# Altium schematic sheet AnalogSignalPath.SchDoc(AnalogSignalPath) (continued)

## Library data of the parts
C25-C32 = C0603C104K5RACAUTO: Multilayer Ceramic Capacitors MLCC - SMD/SMT 50V 0.1uF X7R 0603 10% AEC-Q200; Manufacturer: Yageo Group; Dissipation Factor: 2.5%; Temperature Coefficient: 30ppm/°C; Value: 100nF; Case Code (Imperial): 0603; Case Code (Metric): 1608; Case/Package: 0603; Category: Ceramic Capacitors; China RoHS: Compliant; Component Kind: Standard; ComponentLink1Description: https://datasheet.octopart.com:443/C0603C104K5RACAUTO-KEMET-datasheet-178087915.pdf?src-supplier=Farnell; ComponentLink1URL: https://datasheet.octopart.com:443/C0603C104K5RACAUTO-KEMET-datasheet-178087915.pdf?src-supplier=Farnell; ComponentLink2Description: https://datasheet.octopart.com:443/C0603C104K5RACAUTO-KEMET-datasheet-140480346.pdf?src-supplier=Farnell; ComponentLink2URL: https://datasheet.octopart.com:443/C0603C104K5RACAUTO-KEMET-datasheet-140480346.pdf?src-supplier=Farnell; ComponentLink3Description: https://datasheet.octopart.com:443/C0603C104K5RACAUTO-KEMET-datasheet-138896278.pdf?src-supplier=Farnell; ComponentLink3URL: https://datasheet.octopart.com:443/C0603C104K5RACAUTO-KEMET-datasheet-138896278.pdf?src-supplier=Farnell; ComponentLink4Description: https://datasheet.octopart.com:443/C0603C104K5RACAUTO-KEMET-datasheet-101575653.pdf?src-supplier=Farnell; ComponentLink4URL: https://datasheet.octopart.com:443/C0603C104K5RACAUTO-KEMET-datasheet-101575653.pdf?src-supplier=Farnell; ComponentLink5Description: https://datasheet.octopart.com:443/C0603C104K5RACAUTO-KEMET-datasheet-169773475.pdf?src-supplier=Farnell; ComponentLink5URL: https://datasheet.octopart.com:443/C0603C104K5RACAUTO-KEMET-datasheet-169773475.pdf?src-supplier=Farnell; ComponentLink6Description: https://datasheet.octopart.com:443/C0603C104K5RAC-AUTO-Kemet-datasheet-11517047.pdf?src-supplier=Farnell; ComponentLink6URL: https://datasheet.octopart.com:443/C0603C104K5RAC-AUTO-Kemet-datasheet-11517047.pdf?src-supplier=Farnell; ComponentLink7Description: https://datasheet.octopart.com:443/C0603C104K5RACAUTO-KEMET-datasheet-137357764.pdf?src-supplier=Upverter; ComponentLink7URL: https://datasheet.octopart.com:443/C0603C104K5RACAUTO-KEMET-datasheet-137357764.pdf?src-supplier=Upverter; ComponentLink8Description: https://datasheet.octopart.com:443/C0603C104K5RACAUTO-Kemet-datasheet-17277841.pdf?src-supplier=Newark; ComponentLink8URL: https://datasheet.octopart.com:443/C0603C104K5RACAUTO-Kemet-datasheet-17277841.pdf?src-supplier=Newark; ComponentLink9Description: https://datasheet.octopart.com:443/C0603C104K5RAC-AUTO-Kemet-datasheet-10850653.pdf?src-supplier=Newark; ComponentLink9URL: https://datasheet.octopart.com:443/C0603C104K5RAC-AUTO-Kemet-datasheet-10850653.pdf?src-supplier=Newark; ComponentLink10Description: https://datasheet.octopart.com:443/C0603C104K5RACAUTO-KEMET-datasheet-176289396.pdf?src-supplier=JRH+Electronics; ComponentLink10URL: https://datasheet.octopart.com:443/C0603C104K5RACAUTO-KEMET-datasheet-176289396.pdf?src-supplier=JRH+Electronics; ComponentLink11Description: https://datasheet.octopart.com:443/C0603C104K5RACAUTO-KEMET-datasheet-153410967.pdf?src-supplier=Electro+Sonic; ComponentLink11URL: https://datasheet.octopart.com:443/C0603C104K5RACAUTO-KEMET-datasheet-153410967.pdf?src-supplier=Electro+Sonic; ComponentLink12Description: https://datasheet.octopart.com:443/C0603C104K5RACAUTO-KEMET-datasheet-142166320.pdf?src-supplier=Electro+Sonic; ComponentLink12URL: https://datasheet.octopart.com:443/C0603C104K5RACAUTO-KEMET-datasheet-142166320.pdf?src-supplier=Electro+Sonic; ComponentLink13Description: https://datasheet.octopart.com:443/C0603C104K5RACAUTO-Kemet-datasheet-66076775.pdf?src-supplier=element14+APAC; ComponentLink13URL: https://datasheet.octopart.com:443/C0603C104K5RACAUTO-Kemet-datasheet-66076775.pdf?src-supplier=element14+APAC; ComponentLink14Description: https://datasheet.octopart.com:443/C0603C104K5RACAUTO-Kemet-datasheet-66204749.pdf?src-supplier=element14+APAC; ComponentLink14URL: https://datasheet.octopart.com:443/C0603C104K5RACAUTO-Kemet-datasheet-66204749.pdf?src-supplier=element14+APAC; ComponentLink15Description: https://datasheet.octopart.com:443/C0603C104K5RACAUTO-Kemet-datasheet-66534724.pdf?src-supplier=element14+APAC; ComponentLink15URL: https://datasheet.octopart.com:443/C0603C104K5RACAUTO-Kemet-datasheet-66534724.pdf?src-supplier=element14+APAC; ComponentLink16Description: https://datasheet.octopart.com:443/C0603C104K5RACAUTO-KEMET-datasheet-83968784.pdf?src-supplier=TME; ComponentLink16URL: https://datasheet.octopart.com:443/C0603C104K5RACAUTO-KEMET-datasheet-83968784.pdf?src-supplier=TME; ComponentLink17Description: https://datasheet.octopart.com:443/C0603C104K5RACAUTO-KEMET-datasheet-83186888.pdf?src-supplier=TME; ComponentLink17URL: https://datasheet.octopart.com:443/C0603C104K5RACAUTO-KEMET-datasheet-83186888.pdf?src-supplier=TME; ComponentLink18Description: https://datasheet.octopart.com/C0603C104K5RACAUTO-KEMET-datasheet-84169754.pdf; ComponentLink18URL: https://datasheet.octopart.com/C0603C104K5RACAUTO-KEMET-datasheet-84169754.pdf; ComponentLink19Description: https://datasheet.octopart.com:443/C0603C104K5RACAUTO-KEMET-datasheet-189767472.pdf?src-supplier=ODG+(Origin+Data+Global); ComponentLink19URL: https://datasheet.octopart.com:443/C0603C104K5RACAUTO-KEMET-datasheet-189767472.pdf?src-supplier=ODG+(Origin+Data+Global); ComponentLink20Description: http://sigma.octopart.com:80/conflict_mineral_statement/Yageo-company-3.pdf?src-supplier=Compliance+Statements; ComponentLink20URL: http://sigma.octopart.com:80/conflict_mineral_statement/Yageo-company-3.pdf?src-supplier=Compliance+Statements; ComponentLink21Description: http://sigma.octopart.com:80/rohs_statement/Yageo-company-2.pdf?src-supplier=Compliance+Statements; ComponentLink21URL: http://sigma.octopart.com:80/rohs_statement/Yageo-company-2.pdf?src-supplier=Compliance+Statements; Contact Plating: Tin; Country of Origin: Mexico; Depth: 800µm; Dielectric: X7R; Dielectric Material: Ceramic; Export Control Classification Number (ECCN) Code: EAR99; Harmonized Tariff Schedule (HTS) Code: 8532.24.00.20; Height: 800µm; Introduction Date: 2011-07-27; Length: 1.6mm; Lifecycle Status: Production; Manufacturer Part Number: C0603C104K5RACAUTO; Material: Ceramic; Max Operating Temperature: 125°C; Min Operating Temperature: -55°C; Mounting Technology: Surface Mount; Number of Terminals: 2; Packaging: Cut Tape; Pricing: 1=0.15, 10=0.083, 50=0.0574, 100=0.0497, 500=0.0367 (USD); Radiation Hardening: No; Ratings: AEC-Q200; REACH SVHC: Yes; RoHS: Compliant; Schedule B: 8532240020, 8532240020|8532240020, 8532240020|8532240020|8532240020, 8532240020|8532240020|8532240020|8532240020; Stock: 1408607; Supplier: DigiKey; Supplier Part Number: 399-6856-6-ND; Termination: SMD/SMT; Thickness: 863.6µm; Tolerance: 10%; Voltage Rating: 50V; Voltage Rating (DC): 50V; Width: 800µm; Library Name: Tremelo_Pedal.SchLib
C33 = R82DC3100AA50K: Res Thick Film 0603 10.7K Ohm 1% 0.1W(1/10W) ±100ppm/C Pad SMD T/R Automotive AEC-Q200; Manufacturer: Yageo Group; Manufacturer Lifecycle Status: ACTIVE; Breakdown Voltage: -35V; Continuous Drain Current (ID): 2mA; Dielectric: PET; Dielectric Material: Polyester; Dissipation Factor: 80%; Drain to Source Resistance: 100Ω; Drain to Source Voltage (Vdss): 35V; Element Configuration: Single; Lead Diameter: 500µm; Max Junction Temperature (Tj): 150°C; Max Power Dissipation: 350mW; Number of Channels: 1; Power Rating: 100mW; Temperature Coefficient: 100ppm/°C; Tolerance: 10%; Type: General Purpose; Value: 100nF; Voltage Rating: 63V; Voltage Rating (AC): 40V; Working Voltage: 75V; Case/Package: Radial; Category: Film Capacitors; China RoHS: Compliant; Component Kind: Standard; ComponentLink1Description: https://datasheet.octopart.com:443/R82DC3100AA50K-KEMET-datasheet-182036371.pdf?src-supplier=IHS; ComponentLink1URL: https://datasheet.octopart.com:443/R82DC3100AA50K-KEMET-datasheet-182036371.pdf?src-supplier=IHS; ComponentLink2Description: https://datasheet.octopart.com:443/R82DC3100AA50K-KEMET-datasheet-27203286.pdf?src-supplier=Farnell; ComponentLink2URL: https://datasheet.octopart.com:443/R82DC3100AA50K-KEMET-datasheet-27203286.pdf?src-supplier=Farnell; ComponentLink3Description: https://datasheet.octopart.com:443/R82DC3100AA50K-KEMET-datasheet-86450214.pdf?src-supplier=Farnell; ComponentLink3URL: https://datasheet.octopart.com:443/R82DC3100AA50K-KEMET-datasheet-86450214.pdf?src-supplier=Farnell; ComponentLink4Description: https://datasheet.octopart.com:443/R82DC3100AA50K-KEMET-datasheet-181970238.pdf?src-supplier=Farnell; ComponentLink4URL: https://datasheet.octopart.com:443/R82DC3100AA50K-KEMET-datasheet-181970238.pdf?src-supplier=Farnell; ComponentLink5Description: https://datasheet.octopart.com:443/R82DC3100AA50K-KEMET-datasheet-181970237.pdf?src-supplier=Farnell; ComponentLink5URL: https://datasheet.octopart.com:443/R82DC3100AA50K-KEMET-datasheet-181970237.pdf?src-supplier=Farnell; ComponentLink6Description: https://datasheet.octopart.com:443/R82DC3100AA50K-KEMET-datasheet-182521466.pdf?src-supplier=Farnell; ComponentLink6URL: https://datasheet.octopart.com:443/R82DC3100AA50K-KEMET-datasheet-182521466.pdf?src-supplier=Farnell; ComponentLink7Description: https://datasheet.octopart.com:443/R82DC3100AA50K-KEMET-datasheet-83037516.pdf?src-supplier=Newark; ComponentLink7URL: https://datasheet.octopart.com:443/R82DC3100AA50K-KEMET-datasheet-83037516.pdf?src-supplier=Newark; ComponentLink8Description: https://datasheet.octopart.com:443/R82DC3100AA50K-KEMET-datasheet-137794307.pdf?src-supplier=Newark; ComponentLink8URL: https://datasheet.octopart.com:443/R82DC3100AA50K-KEMET-datasheet-137794307.pdf?src-supplier=Newark; ComponentLink9Description: https://datasheet.octopart.com:443/R82DC3100AA50K-KEMET-datasheet-119327201.pdf?src-supplier=TME; ComponentLink9URL: https://datasheet.octopart.com:443/R82DC3100AA50K-KEMET-datasheet-119327201.pdf?src-supplier=TME; ComponentLink10Description: https://datasheet.octopart.com:443/R82DC3100AA50K-Kemet-datasheet-62346237.pdf?src-supplier=TME; ComponentLink10URL: https://datasheet.octopart.com:443/R82DC3100AA50K-Kemet-datasheet-62346237.pdf?src-supplier=TME; ComponentLink11Description: https://datasheet.octopart.com:443/R82DC3100AA50K-Kemet-datasheet-34151649.pdf?src-supplier=TME; ComponentLink11URL: https://datasheet.octopart.com:443/R82DC3100AA50K-Kemet-datasheet-34151649.pdf?src-supplier=TME; ComponentLink12Description: https://datasheet.octopart.com:443/82DC3100AA50K-Kemet-datasheet-11776859.pdf?src-supplier=Arrow+Electronics; ComponentLink12URL: https://datasheet.octopart.com:443/82DC3100AA50K-Kemet-datasheet-11776859.pdf?src-supplier=Arrow+Electronics; ComponentLink13Description: http://sigma.octopart.com:80/conflict_mineral_statement/Yageo-company-3.pdf?src-supplier=Compliance+Statements; ComponentLink13URL: http://sigma.octopart.com:80/conflict_mineral_statement/Yageo-company-3.pdf?src-supplier=Compliance+Statements; ComponentLink14Description: http://sigma.octopart.com:80/rohs_statement/Yageo-company-2.pdf?src-supplier=Compliance+Statements; ComponentLink14URL: http://sigma.octopart.com:80/rohs_statement/Yageo-company-2.pdf?src-supplier=Compliance+Statements; ComponentLink15Description: https://datasheet.octopart.com:443/MMBFJ113-onsemi-datasheet-175423460.pdf?src-supplier=IHS; ComponentLink15URL: https://datasheet.octopart.com:443/MMBFJ113-onsemi-datasheet-175423460.pdf?src-supplier=IHS; ComponentLink16Description: https://datasheet.octopart.com:443/MMBFJ113-ON-Semiconductor-datasheet-98551334.pdf?src-supplier=JRH+Electronics; ComponentLink16URL: https://datasheet.octopart.com:443/MMBFJ113-ON-Semiconductor-datasheet-98551334.pdf?src-supplier=JRH+Electronics; ComponentLink17Description: https://datasheet.octopart.com:443/MMBFJ113-Fairchild-Semiconductor-datasheet-37672063.pdf?src-supplier=element14+APAC; ComponentLink17URL: https://datasheet.octopart.com:443/MMBFJ113-Fairchild-Semiconductor-datasheet-37672063.pdf?src-supplier=element14+APAC; ComponentLink18Description: https://datasheet.octopart.com:443/MMBFJ113-ON-Semiconductor-datasheet-86691273.pdf?src-supplier=element14+APAC; ComponentLink18URL: https://datasheet.octopart.com:443/MMBFJ113-ON-Semiconductor-datasheet-86691273.pdf?src-supplier=element14+APAC; ComponentLink19Description: https://datasheet.octopart.com:443/MMBFJ113.-Fairchild-Semiconductor-datasheet-67621447.pdf?src-supplier=element14+APAC; ComponentLink19URL: https://datasheet.octopart.com:443/MMBFJ113.-Fairchild-Semiconductor-datasheet-67621447.pdf?src-supplier=element14+APAC; ComponentLink20Description: https://datasheet.octopart.com:443/MMBFJ113.-Fairchild-Semiconductor-datasheet-78863382.pdf?src-supplier=element14+APAC; ComponentLink20URL: https://datasheet.octopart.com:443/MMBFJ113.-Fairchild-Semiconductor-datasheet-78863382.pdf?src-supplier=element14+APAC; ComponentLink21Description: https://sigma.octopart.com:443/138897312/technical_drawing/ON-Semiconductor-MMBFJ113.pdf?src-supplier=Upverter; ComponentLink21URL: https://sigma.octopart.com:443/138897312/technical_drawing/ON-Semiconductor-MMBFJ113.pdf?src-supplier=Upverter; ComponentLink22Description: https://datasheet.octopart.com:443/MMBFJ113-ON-Semiconductor-datasheet-12544084.pdf?src-supplier=Farnell; ComponentLink22URL: https://datasheet.octopart.com:443/MMBFJ113-ON-Semiconductor-datasheet-12544084.pdf?src-supplier=Farnell; ComponentLink23Description: https://datasheet.octopart.com:443/MMBFJ113.-Fairchild-Semiconductor-datasheet-68613694.pdf?src-supplier=Farnell; ComponentLink23URL: https://datasheet.octopart.com:443/MMBFJ113.-Fairchild-Semiconductor-datasheet-68613694.pdf?src-supplier=Farnell; ComponentLink24Description: https://datasheet.octopart.com:443/MMBFJ113.-ON-Semiconductor-datasheet-86691274.pdf?src-supplier=Farnell; ComponentLink24URL: https://datasheet.octopart.com:443/MMBFJ113.-ON-Semiconductor-datasheet-86691274.pdf?src-supplier=Farnell; ComponentLink25Description: https://datasheet.octopart.com:443/MMBFJ113-Fairchild-datasheet-11657.pdf?src-supplier=Arrow+Electronics; ComponentLink25URL: https://datasheet.octopart.com:443/MMBFJ113-Fairchild-datasheet-11657.pdf?src-supplier=Arrow+Electronics; ComponentLink26Description: https://datasheet.octopart.com:443/MMBFJ113-ON-Semiconductor-datasheet-85403384.pdf?src-supplier=onsemi; ComponentLink26URL: https://datasheet.octopart.com:443/MMBFJ113-ON-Semiconductor-datasheet-85403384.pdf?src-supplier=onsemi; ComponentLink27Description: https://datasheet.octopart.com:443/MMBFJ113-ON-Semiconductor-datasheet-86697995.pdf?src-supplier=onsemi; ComponentLink27URL: https://datasheet.octopart.com:443/MMBFJ113-ON-Semiconductor-datasheet-86697995.pdf?src-supplier=onsemi; ComponentLink28Description: https://datasheet.octopart.com:443/MMBFJ113-onsemi-datasheet-166055816.pdf?src-supplier=Newark; ComponentLink28URL: https://datasheet.octopart.com:443/MMBFJ113-onsemi-datasheet-166055816.pdf?src-supplier=Newark; ComponentLink29Description: https://datasheet.octopart.com:443/MMBFJ113-Fairchild-Semiconductor-datasheet-67809905.pdf?src-supplier=Newark; ComponentLink29URL: https://datasheet.octopart.com:443/MMBFJ113-Fairchild-Semiconductor-datasheet-67809905.pdf?src-supplier=Newark; ComponentLink30Description: https://datasheet.octopart.com:443/MMBFJ113-Fairchild-Semiconductor-datasheet-14042844.pdf?src-supplier=iiiC; ComponentLink30URL: https://datasheet.octopart.com:443/MMBFJ113-Fairchild-Semiconductor-datasheet-14042844.pdf?src-supplier=iiiC; ComponentLink31Description: https://sigma.octopart.com:443/17343971/technical_drawing/Fairchild-Semiconductor-MMBFJ113.pdf?src-supplier=Fairchild+Semiconductor; ComponentLink31URL: https://sigma.octopart.com:443/17343971/technical_drawing/Fairchild-Semiconductor-MMBFJ113.pdf?src-supplier=Fairchild+Semiconductor; ComponentLink32Description: http://sigma.octopart.com:80/150618358/rohs_statement/ON-Semiconductor-MMBFJ113.pdf?src-supplier=Compliance+Statements; ComponentLink32URL: http://sigma.octopart.com:80/150618358/rohs_statement/ON-Semiconductor-MMBFJ113.pdf?src-supplier=Compliance+Statements; ComponentLink33Description: http://sigma.octopart.com:80/17354741/reach_statement/Fairchild-Semiconductor-MMBFJ113.pdf?src-supplier=Compliance+Statements; ComponentLink33URL: http://sigma.octopart.com:80/17354741/reach_statement/Fairchild-Semiconductor-MMBFJ113.pdf?src-supplier=Compliance+Statements; ComponentLink34Description: http://sigma.octopart.com:80/17354742/materials_sheet/Fairchild-Semiconductor-MMBFJ113.pdf?src-supplier=Compliance+Statements; ComponentLink34URL: http://sigma.octopart.com:80/17354742/materials_sheet/Fairchild-Semiconductor-MMBFJ113.pdf?src-supplier=Compliance+Statements; ComponentLink35Description: http://sigma.octopart.com:80/33234283/reach_statement/Fairchild-Semiconductor-MMBFJ113.pdf?src-supplier=Compliance+Statements; ComponentLink35URL: http://sigma.octopart.com:80/33234283/reach_statement/Fairchild-Semiconductor-MMBFJ113.pdf?src-supplier=Compliance+Statements; ComponentLink36Description: http://sigma.octopart.com:80/33234294/materials_sheet/Fairchild-Semiconductor-MMBFJ113.pdf?src-supplier=Compliance+Statements; ComponentLink36URL: http://sigma.octopart.com:80/33234294/materials_sheet/Fairchild-Semiconductor-MMBFJ113.pdf?src-supplier=Compliance+Statements; ComponentLink37Description: http://sigma.octopart.com:80/reach_statement/ON-Semiconductor-company-79.pdf?src-supplier=Compliance+Statements; ComponentLink37URL: http://sigma.octopart.com:80/reach_statement/ON-Semiconductor-company-79.pdf?src-supplier=Compliance+Statements; ComponentLink38Description: http://sigma.octopart.com:80/rohs_statement/Fairchild-Semiconductor-company-11.pdf?src-supplier=Compliance+Statements; ComponentLink38URL: http://sigma.octopart.com:80/rohs_statement/Fairchild-Semiconductor-company-11.pdf?src-supplier=Compliance+Statements; ComponentLink39Description: http://sigma.octopart.com:80/rohs_statement/Fairchild-Semiconductor-company-10.pdf?src-supplier=Compliance+Statements; ComponentLink39URL: http://sigma.octopart.com:80/rohs_statement/Fairchild-Semiconductor-company-10.pdf?src-supplier=Compliance+Statements; ComponentLink40Description: http://sigma.octopart.com:80/reach_statement/Fairchild-Semiconductor-company-9.pdf?src-supplier=Compliance+Statements; ComponentLink40URL: http://sigma.octopart.com:80/reach_statement/Fairchild-Semiconductor-company-9.pdf?src-supplier=Compliance+Statements; ComponentLink41Description: https://octopart.com:443/opatz8j6/c1?t=8PanoNSBlo3yG8KwpwqyyS7rBjjXF68d2rudrC8xt_EgN37ME7PSrsQywIlMWsWrucB2TrXHVGXRGbyNTgb8bYMl2RhHQQ7Yle981BHCj1qRvCRbbDkdoQkn3-U6oR0VxcMWbPOPgWMExOqWcu4ZdZkgZntONni_lN5SaDdUI-LGblQ0MXX3LYp0Zya8JkVvifZtlbuynVzqi1WXyyPwKTRGFRZeVqRwQx60nrSn0eWSI4xujBM5M2CdHCWqonFB2R6fCJkXQMUhjq3mD_XXHRFkqXH50fe3adWw72sxAH-JqJtMFdLc6hIZGzbORYZ-lwjrCwXnDujmsQbr831iiRPhc2MbYl-UYjjh&src-supplier=Compliance+Statements; ComponentLink41URL: https://octopart.com:443/opatz8j6/c1?t=8PanoNSBlo3yG8KwpwqyyS7rBjjXF68d2rudrC8xt_EgN37ME7PSrsQywIlMWsWrucB2TrXHVGXRGbyNTgb8bYMl2RhHQQ7Yle981BHCj1qRvCRbbDkdoQkn3-U6oR0VxcMWbPOPgWMExOqWcu4ZdZkgZntONni_lN5SaDdUI-LGblQ0MXX3LYp0Zya8JkVvifZtlbuynVzqi1WXyyPwKTRGFRZeVqRwQx60nrSn0eWSI4xujBM5M2CdHCWqonFB2R6fCJkXQMUhjq3mD_XXHRFkqXH50fe3adWw72sxAH-JqJtMFdLc6hIZGzbORYZ-lwjrCwXnDujmsQbr831iiRPhc2MbYl-UYjjh&src-supplier=Compliance+Statements; ComponentLink42Description: https://octopart.com:443/opatz8j6/c1?t=O-NDeCLSr2eHDdFGOF77dY3FtXXuHkEXjzlbp7Z0RAUm9rKxTgB7X2QHCo0xZct10yZbrs5J17Iu7XXlXTvvZbBOfFaRl9Pe731Q_4qFekQEZAMIFdAqqz1hF0e66CE3B1IVDm6W9o8a-kCQYFZLz67nQuu4TH9Kz_XChhHPfv-WiDMA-mU5WM4Z24CI_yBWZR7q8tmIyYoKRVFysjvRRMdgAxbrnmVmfBytZ0Ym1atQKk8nTNT53asBbqoegkybOK9Czu0o-USlqnVYzd8mz4KQIZiIeF_qlQj75_BhnFsWqTIR559beiihauWKVT_zsDNGyQrogfju4ly1aBo4psB_NQLY4y3R9CvwyA&src-supplier=Compliance+Statements; ComponentLink42URL: https://octopart.com:443/opatz8j6/c1?t=O-NDeCLSr2eHDdFGOF77dY3FtXXuHkEXjzlbp7Z0RAUm9rKxTgB7X2QHCo0xZct10yZbrs5J17Iu7XXlXTvvZbBOfFaRl9Pe731Q_4qFekQEZAMIFdAqqz1hF0e66CE3B1IVDm6W9o8a-kCQYFZLz67nQuu4TH9Kz_XChhHPfv-WiDMA-mU5WM4Z24CI_yBWZR7q8tmIyYoKRVFysjvRRMdgAxbrnmVmfBytZ0Ym1atQKk8nTNT53asBbqoegkybOK9Czu0o-USlqnVYzd8mz4KQIZiIeF_qlQj75_BhnFsWqTIR559beiihauWKVT_zsDNGyQrogfju4ly1aBo4psB_NQLY4y3R9CvwyA&src-supplier=Compliance+Statements; ComponentLink43Description: https://datasheet.octopart.com:443/AC0603FR-0710K7L-Yageo-datasheet-182189377.pdf?src-supplier=IHS; ComponentLink43URL: https://datasheet.octopart.com:443/AC0603FR-0710K7L-Yageo-datasheet-182189377.pdf?src-supplier=IHS; ComponentLink44Description: https://datasheet.octopart.com:443/AC0603FR-0710K7L-Yageo-datasheet-86786639.pdf?src-supplier=Upverter; ComponentLink44URL: https://datasheet.octopart.com:443/AC0603FR-0710K7L-Yageo-datasheet-86786639.pdf?src-supplier=Upverter; ComponentLink45Description: https://datasheet.octopart.com:443/AC0603FR-0710K7L-Yageo-datasheet-178509465.pdf?src-supplier=Farnell; ComponentLink45URL: https://datasheet.octopart.com:443/AC0603FR-0710K7L-Yageo-datasheet-178509465.pdf?src-supplier=Farnell; ComponentLink46Description: https://datasheet.octopart.com:443/AC0603FR-0710K7L-Yageo-Group-datasheet-189830439.pdf?src-supplier=Future+Electronics; ComponentLink46URL: https://datasheet.octopart.com:443/AC0603FR-0710K7L-Yageo-Group-datasheet-189830439.pdf?src-supplier=Future+Electronics; ComponentLink47Description: https://datasheet.octopart.com:443/AC0603FR-0710K7L-Yageo-datasheet-166720450.pdf?src-supplier=Future+Electronics; ComponentLink47URL: https://datasheet.octopart.com:443/AC0603FR-0710K7L-Yageo-datasheet-166720450.pdf?src-supplier=Future+Electronics; ComponentLink48Description: https://datasheet.octopart.com:443/AC0603FR-0710K7L-Yageo-datasheet-164768995.pdf?src-supplier=Future+Electronics; ComponentLink48URL: https://datasheet.octopart.com:443/AC0603FR-0710K7L-Yageo-datasheet-164768995.pdf?src-supplier=Future+Electronics; ComponentLink49Description: https://datasheet.octopart.com:443/AC0603FR-0710K7L-Yageo-Group-datasheet-188689268.pdf?src-supplier=LCSC; ComponentLink49URL: https://datasheet.octopart.com:443/AC0603FR-0710K7L-Yageo-Group-datasheet-188689268.pdf?src-supplier=LCSC; ComponentLink50Description: https://datasheet.octopart.com/AC0603FR-0710K7L-Yageo-datasheet-12512238.pdf; ComponentLink50URL: https://datasheet.octopart.com/AC0603FR-0710K7L-Yageo-datasheet-12512238.pdf; Composition: Thick Film; Contact Plating: Tin; Country of Origin: Mainland China, Taiwan; Current Rating: 2mA; Depth: 2.5mm; Export Control Classification Number (ECCN) Code: EAR99; Features: Automotive AEC-Q200, Moisture Resistant; Gate to Source Voltage (Vgs): -35V; Harmonized Tariff Schedule (HTS) Code: 8532.25.00.70; Height: 6.5mm; Height - Seated (Max): 6.5024mm; Introduction Date: 2010-04-16; Lead Free: Lead Free; Lead Pitch: 5mm; Length: 7.2mm; Lifecycle Status: Production; Manufacturer Part Number: R82DC3100AA50K; Max Operating Temperature: 105°C; Min Operating Temperature: -55°C; Mounting Technology: Through Hole; Number of Elements: 1; Number of Terminals: 2; Number of Terminations: 2; Packaging: Bulk; Pins: 3; Power Dissipation: 350mW; Pricing: 1=0.28, 10=0.166, 50=0.12, 100=0.1061, 500=0.08258, 1000=0.07534, 3000=0.06641, 6000=0.06204 (USD); Radiation Hardening: No; Ratings: AEC-Q200; REACH SVHC: Yes; RoHS: Compliant; Schedule B: 8532250070, 8532250080, 8532250080|8532250080, 8532250080|8532250080|8532250080; Stock: 7829; Supplier: DigiKey; Supplier Part Number: 399-9684-ND; Terminal Pitch: 5mm; Termination: Radial; Voltage Rating (DC): 63V; Weight: 30mg; Width: 2.5mm; Library Name: Tremelo_Pedal.SchLib
C34 = B32522C0475J000: Cap Film 4.7uF 63V PET 5% (18 X 7 X 12.5mm) Radial Stacked 15mm 125C Automotive Bulk; Manufacturer: EPCOS; Dielectric Material: Polyester; Value: 4.7µF; Voltage Rating (AC): 40V; Case/Package: Radial; Category: Film Capacitors; China RoHS: Compliant; Component Kind: Standard; ComponentLink1Description: https://datasheet.octopart.com:443/B32522C0475J000-EPCOS-datasheet-154543793.pdf?src-supplier=IHS; ComponentLink1URL: https://datasheet.octopart.com:443/B32522C0475J000-EPCOS-datasheet-154543793.pdf?src-supplier=IHS; ComponentLink2Description: https://datasheet.octopart.com:443/B32522C0475J000-EPCOS-datasheet-32747365.pdf?src-supplier=Newark; ComponentLink2URL: https://datasheet.octopart.com:443/B32522C0475J000-EPCOS-datasheet-32747365.pdf?src-supplier=Newark; ComponentLink3Description: https://datasheet.octopart.com:443/B32522C0475J000-EPCOS-datasheet-44890114.pdf?src-supplier=Newark; ComponentLink3URL: https://datasheet.octopart.com:443/B32522C0475J000-EPCOS-datasheet-44890114.pdf?src-supplier=Newark; ComponentLink4Description: https://datasheet.octopart.com:443/B32522C0475J000-TDK-datasheet-136998817.pdf?src-supplier=Ciiva; ComponentLink4URL: https://datasheet.octopart.com:443/B32522C0475J000-TDK-datasheet-136998817.pdf?src-supplier=Ciiva; ComponentLink5Description: https://datasheet.octopart.com:443/B32522C0475J000-EPCOS-datasheet-128073551.pdf?src-supplier=TME; ComponentLink5URL: https://datasheet.octopart.com:443/B32522C0475J000-EPCOS-datasheet-128073551.pdf?src-supplier=TME; Dielectric: PET; Export Control Classification Number (ECCN) Code: EAR99; Harmonized Tariff Schedule (HTS) Code: 8532.25.00.70; Height: 12.5mm; Introduction Date: 2000-09-29; Lead Pitch: 15mm; Length: 18mm; Lifecycle Status: Production; Manufacturer Part Number: B32522C0475J000; Max Length: 18mm; Max Operating Temperature: 125°C; Max Width: 7mm; Min Operating Temperature: -55°C; Mounting Technology: Through Hole; Number of Terminals: 2; Packaging: Bulk; Pricing: 1=2.52, 10=1.728, 50=1.413, 100=1.3158, 500=1.15126, 1000=1.10033, 3000=1.03738, 5000=1.01409 (USD); Ratings: AEC-Q200; REACH SVHC: Yes; RoHS: Compliant; Schedule B: 8532250010; Stock: 9221; Supplier: DigiKey; Supplier Part Number: 495-1131-ND; Terminal Pitch: 15mm; Tolerance: 5%; Voltage Rating: 63V; Voltage Rating (DC): 63V; Width: 7mm; Library Name: Tremelo_Pedal.SchLib
C35 = R82EC3150SH70J: Film Cap 0.15uF 100V Ammo Pack; Manufacturer: Yageo Group; Tolerance: 5%; Type: General Purpose; Value: 150nF; Case/Package: Radial; Category: Film Capacitors; Component Kind: Standard; ComponentLink1Description: https://datasheet.octopart.com:443/R82EC3150SH70J-KEMET-datasheet-188519356.pdf?src-supplier=Master+Electronics; ComponentLink1URL: https://datasheet.octopart.com:443/R82EC3150SH70J-KEMET-datasheet-188519356.pdf?src-supplier=Master+Electronics; ComponentLink2Description: https://datasheet.octopart.com:443/R82EC3150SH70J-KEMET-datasheet-182768375.pdf?src-supplier=JRH+Electronics; ComponentLink2URL: https://datasheet.octopart.com:443/R82EC3150SH70J-KEMET-datasheet-182768375.pdf?src-supplier=JRH+Electronics; Manufacturer Part Number: R82EC3150SH70J; Max Operating Temperature: 105°C; Min Operating Temperature: -55°C; Pricing: 2500=0.08802, 5000=0.08243, 7500=0.07964, 12500=0.07654, 17500=0.07473, 25000=0.07299 (USD); RoHS: Compliant; Stock: 30562; Supplier: DigiKey; Supplier Part Number: 399-R82EC3150SH70JTB-ND; Termination: Radial; Voltage Rating: 100V; Library Name: Tremelo_Pedal.SchLib
J2 J3 = RA49B11: Conn 1/4\" Phone Jack F 2 POS 7.62mm Solder RA Thru-Hole 4 Terminal 1 Port; Manufacturer: Switchcraft; Gender: Female; Number of Poles: 2; Number of Ports: 1; Shielding: Unshielded; Category: Connectors; Color: Black; Component Kind: Standard; ComponentLink1Description: https://datasheet.octopart.com:443/RA49B11-Switchcraft-datasheet-13685843.pdf?src-supplier=Master+Electronics; ComponentLink1URL: https://datasheet.octopart.com:443/RA49B11-Switchcraft-datasheet-13685843.pdf?src-supplier=Master+Electronics; ComponentLink2Description: https://datasheet.octopart.com:443/RA49B11-Switchcraft-datasheet-13537009.pdf?src-supplier=Sager+Electronics; ComponentLink2URL: https://datasheet.octopart.com:443/RA49B11-Switchcraft-datasheet-13537009.pdf?src-supplier=Sager+Electronics; ComponentLink3Description: https://datasheet.octopart.com:443/RA49B11-Switchcraft-datasheet-76366.pdf?src-supplier=Mouser; ComponentLink3URL: https://datasheet.octopart.com:443/RA49B11-Switchcraft-datasheet-76366.pdf?src-supplier=Mouser; Contact Plating: Silver; Country of Origin: USA; Depth: 34.03mm; Export Control Classification Number (ECCN) Code: EAR99; Harmonized Tariff Schedule (HTS) Code: 8536.69.40.10; Housing Color: Black; Housing Material: Thermoplastic; Introduction Date: 1997-12-01; Lead Free: Lead Free; Length: 15.74mm; Lifecycle Status: Production; Manufacturer Part Number: RA49B11; Mounting Technology: Through Hole; Number of Positions: 2; Number of Terminals: 4; Orientation: Right Angle; Pitch: 7.62mm; Pricing: 1=4.46, 10=3.793, 25=3.5556, 50=3.3856, 100=3.2239, 300=2.98343, 500=2.87784, 1000=2.74056, 2500=2.56916 (USD); REACH SVHC: Yes; RoHS: Compliant; Stock: 436; Supplier: DigiKey; Supplier Part Number: SC2546-ND; Termination: Solder; Library Name: Tremelo_Pedal.SchLib
Q2 = MMBFJ113: onsemi JFET, Npassageway, surface mount installation, SOT-23encapsulation, 3Pin, Idss: min. 2mA; Component Kind: Standard; Library Name: Tremelo_Pedal.SchLib
R4 = RNCP0603FTD9K09: Fixed Resistor, Thin Film, 0.125W, 9090ohm, 150V, 1% +/-Tol, 100ppm/Cel, Surface Mount, 0603; Manufacturer: Stackpole Electronics; Max Power Dissipation: 125mW; Temperature Coefficient: 100ppm/°C; Value: 9.09kΩ; Case Code (Imperial): 0603; Case Code (Metric): 1608; Case/Package: 0603; Category: Chip SMD Resistors; Component Kind: Standard; ComponentLink1Description: https://datasheet.octopart.com:443/RNCP0603FTD9K09-Stackpole-Electronics-datasheet-182148179.pdf?src-supplier=IHS; ComponentLink1URL: https://datasheet.octopart.com:443/RNCP0603FTD9K09-Stackpole-Electronics-datasheet-182148179.pdf?src-supplier=IHS; ComponentLink2Description: https://datasheet.octopart.com:443/RNCP0603FTD9K09-Stackpole-Electronics-datasheet-149178200.pdf?src-supplier=Upverter; ComponentLink2URL: https://datasheet.octopart.com:443/RNCP0603FTD9K09-Stackpole-Electronics-datasheet-149178200.pdf?src-supplier=Upverter; ComponentLink3Description: https://datasheet.octopart.com:443/RNCP0603FTD9K09-Stackpole-Electronics-datasheet-141193801.pdf?src-supplier=Stackpole+Electronics; ComponentLink3URL: https://datasheet.octopart.com:443/RNCP0603FTD9K09-Stackpole-Electronics-datasheet-141193801.pdf?src-supplier=Stackpole+Electronics; ComponentLink4Description: https://datasheet.octopart.com:443/RNCP0603FTD9K09-Stackpole-Electronics-datasheet-38896780.pdf?src-supplier=Stackpole+Electronics; ComponentLink4URL: https://datasheet.octopart.com:443/RNCP0603FTD9K09-Stackpole-Electronics-datasheet-38896780.pdf?src-supplier=Stackpole+Electronics; ComponentLink5Description: http://sigma.octopart.com:80/15942470/materials_sheet/Stackpole-Electronics-RNCP0603FTD9K09.pdf?src-supplier=Compliance+Statements; ComponentLink5URL: http://sigma.octopart.com:80/15942470/materials_sheet/Stackpole-Electronics-RNCP0603FTD9K09.pdf?src-supplier=Compliance+Statements; ComponentLink6Description: http://sigma.octopart.com:80/141158211/reach_statement/Stackpole-Electronics-RNCP0603FTD9K09.pdf?src-supplier=Compliance+Statements; ComponentLink6URL: http://sigma.octopart.com:80/141158211/reach_statement/Stackpole-Electronics-RNCP0603FTD9K09.pdf?src-supplier=Compliance+Statements; ComponentLink7Description: http://sigma.octopart.com:80/141158212/rohs_statement/Stackpole-Electronics-RNCP0603FTD9K09.pdf?src-supplier=Compliance+Statements; ComponentLink7URL: http://sigma.octopart.com:80/141158212/rohs_statement/Stackpole-Electronics-RNCP0603FTD9K09.pdf?src-supplier=Compliance+Statements; Composition: Thin Film; Features: Anti-Sulfur, Moisture Resistant; Height: 400µm; Lead Free: Lead Free; Length: 1.55mm; Lifecycle Status: Production; Manufacturer Part Number: RNCP0603FTD9K09; Max Operating Temperature: 155°C; Min Operating Temperature: -55°C; Mounting Technology: Surface Mount; Number of Terminals: 2; Number of Terminations: 2; Packaging: Cut Tape (CT); Power Rating: 125mW; Pricing: 5000=0.00777, 15000=0.00718 (USD); Ratings: AEC-Q200; REACH SVHC: Yes; RoHS: Compliant; Schedule B: 8533210045, 8533210045|8533210045|8533210045|8533210045|8533210045; Stock: 13823; Supplier: DigiKey; Supplier Part Number: RNCP0603FTD9K09TR-ND; Tolerance: 1%; Voltage Rating: 150V; Width: 800µm; Working Voltage: 150V; Library Name: Tremelo_Pedal.SchLib
R5 R8 = MCT06030D1002DP500: Res Thin Film 0603 10K Ohm 0.5% 1/10W ±25ppm/°C Molded SMD SMD Paper T/R; Manufacturer: Vishay; Max Power Dissipation: 125mW; Power Rating: 100mW; Value: 10kΩ; Voltage Rating: 75V; Case Code (Imperial): 0603; Case Code (Metric): 1608; Case/Package: 0603; Category: Chip SMD Resistors; China RoHS: Non-Compliant; Component Kind: Standard; ComponentLink1Description: https://datasheet.octopart.com:443/MCT06030D1002DP500-Vishay-datasheet-182027961.pdf?src-supplier=IHS; ComponentLink1URL: https://datasheet.octopart.com:443/MCT06030D1002DP500-Vishay-datasheet-182027961.pdf?src-supplier=IHS; ComponentLink2Description: https://datasheet.octopart.com:443/MCT06030D1002DP500-Vishay-datasheet-81842982.pdf?src-supplier=Future+Electronics; ComponentLink2URL: https://datasheet.octopart.com:443/MCT06030D1002DP500-Vishay-datasheet-81842982.pdf?src-supplier=Future+Electronics; ComponentLink3Description: https://datasheet.octopart.com:443/MCT06030D1002DP500-Vishay-datasheet-178522811.pdf?src-supplier=Newark; ComponentLink3URL: https://datasheet.octopart.com:443/MCT06030D1002DP500-Vishay-datasheet-178522811.pdf?src-supplier=Newark; ComponentLink4Description: https://datasheet.octopart.com:443/MCT06030D1002DP500-Vishay-datasheet-66078241.pdf?src-supplier=Newark; ComponentLink4URL: https://datasheet.octopart.com:443/MCT06030D1002DP500-Vishay-datasheet-66078241.pdf?src-supplier=Newark; ComponentLink5Description: https://datasheet.octopart.com:443/MCT06030D1002DP500-Vishay-Dale-datasheet-62311667.pdf?src-supplier=RS+(Formerly+Allied+Electronics); ComponentLink5URL: https://datasheet.octopart.com:443/MCT06030D1002DP500-Vishay-Dale-datasheet-62311667.pdf?src-supplier=RS+(Formerly+Allied+Electronics); ComponentLink6Description: https://datasheet.octopart.com/MCT06030D1002DP500-Vishay-datasheet-12543201.pdf; ComponentLink6URL: https://datasheet.octopart.com/MCT06030D1002DP500-Vishay-datasheet-12543201.pdf; ComponentLink7Description: https://datasheet.octopart.com/MCT06030D1002DP500-Vishay-datasheet-12532999.pdf; ComponentLink7URL: https://datasheet.octopart.com/MCT06030D1002DP500-Vishay-datasheet-12532999.pdf; ComponentLink8Description: https://datasheet.octopart.com/MCT06030D1002DP500-Vishay-datasheet-12520289.pdf; ComponentLink8URL: https://datasheet.octopart.com/MCT06030D1002DP500-Vishay-datasheet-12520289.pdf; ComponentLink9Description: https://datasheet.octopart.com/MCT06030D1002DP500-Vishay-datasheet-12510709.pdf; ComponentLink9URL: https://datasheet.octopart.com/MCT06030D1002DP500-Vishay-datasheet-12510709.pdf; ComponentLink10Description: http://sigma.octopart.com:80/rohs_statement/Vishay-company-15.pdf?src-supplier=Compliance+Statements; ComponentLink10URL: http://sigma.octopart.com:80/rohs_statement/Vishay-company-15.pdf?src-supplier=Compliance+Statements; Composition: Thin Film; Contact Plating: Tin; Country of Origin: Germany, Israel; Depth: 850µm; Export Control Classification Number (ECCN) Code: EAR99; Harmonized Tariff Schedule (HTS) Code: 8533.21.00.30; Height: 450µm; Introduction Date: 2008-03-17; Lead Free: Lead Free; Length: 1.55mm; Lifecycle Status: Production; Manufacturer Part Number: MCT06030D1002DP500; Max Operating Temperature: 125°C; Military Standard: Not; Min Operating Temperature: -55°C; Mounting Technology: Surface Mount; Number of Terminals: 2; Number of Terminations: 2; Pricing: 1=0.1, 10=0.066, 50=0.058, 100=0.0548, 500=0.04808 (USD); Radiation Hardening: No; REACH SVHC: Yes; RoHS: Compliant; Schedule B: 8533210045, 8533210045|8533210045|8533210045|8533210045|8533210045; Stock: 18426; Supplier: DigiKey; Supplier Part Number: 749-1695-6-ND; Temperature Coefficient: 25ppm/°C; Tolerance: 0.5%; Voltage Rating (DC): 75V; Width: 850µm; Working Voltage: 75V; Library Name: Tremelo_Pedal.SchLib
R6 R14 = CR0603-JW-102ELF: Res Thick Film 0603 1K Ohm 5% 1/10W ±200ppm/°C Molded SMD SMD Paper Tape on Plastic Reel; Manufacturer: Bourns; Max Power Dissipation: 100mW; Value: 1kΩ; Voltage Rating: 75V; Voltage Rating (DC): 50V; Case Code (Imperial): 0603; Case Code (Metric): 1608; Case/Package: 0603; Category: Chip SMD Resistors; China RoHS: Non-Compliant; Component Kind: Standard; ComponentLink1Description: https://datasheet.octopart.com:443/CR0603-JW-102ELF-Bourns-datasheet-159578630.pdf?src-supplier=ODG+(Origin+Data+Global); ComponentLink1URL: https://datasheet.octopart.com:443/CR0603-JW-102ELF-Bourns-datasheet-159578630.pdf?src-supplier=ODG+(Origin+Data+Global); ComponentLink2Description: https://datasheet.octopart.com:443/CR0603-JW-102ELF-Bourns-datasheet-181955239.pdf?src-supplier=IHS; ComponentLink2URL: https://datasheet.octopart.com:443/CR0603-JW-102ELF-Bourns-datasheet-181955239.pdf?src-supplier=IHS; ComponentLink3Description: https://datasheet.octopart.com:443/CR0603-JW-102ELF-Bourns-datasheet-68301634.pdf?src-supplier=element14+APAC; ComponentLink3URL: https://datasheet.octopart.com:443/CR0603-JW-102ELF-Bourns-datasheet-68301634.pdf?src-supplier=element14+APAC; ComponentLink4Description: https://datasheet.octopart.com:443/CR0603-JW-102ELF-Bourns-datasheet-34229249.pdf?src-supplier=element14+APAC; ComponentLink4URL: https://datasheet.octopart.com:443/CR0603-JW-102ELF-Bourns-datasheet-34229249.pdf?src-supplier=element14+APAC; ComponentLink5Description: https://datasheet.octopart.com/CR0603-JW-102ELF-Bourns-datasheet-5318152.pdf; ComponentLink5URL: https://datasheet.octopart.com/CR0603-JW-102ELF-Bourns-datasheet-5318152.pdf; ComponentLink6Description: https://datasheet.octopart.com:443/CR0603-JW-102ELF-Bourns-datasheet-154729663.pdf?src-supplier=Electro+Sonic; ComponentLink6URL: https://datasheet.octopart.com:443/CR0603-JW-102ELF-Bourns-datasheet-154729663.pdf?src-supplier=Electro+Sonic; ComponentLink7Description: https://datasheet.octopart.com:443/CR0603-JW-102ELF-Bourns-datasheet-11547086.pdf?src-supplier=Electro+Sonic; ComponentLink7URL: https://datasheet.octopart.com:443/CR0603-JW-102ELF-Bourns-datasheet-11547086.pdf?src-supplier=Electro+Sonic; ComponentLink8Description: https://datasheet.octopart.com:443/CR0603-JW-102ELF-Bourns-datasheet-49799764.pdf?src-supplier=Farnell; ComponentLink8URL: https://datasheet.octopart.com:443/CR0603-JW-102ELF-Bourns-datasheet-49799764.pdf?src-supplier=Farnell; ComponentLink9Description: https://datasheet.octopart.com:443/CR0603-JW-102ELF-Bourns-datasheet-24895818.pdf?src-supplier=Farnell; ComponentLink9URL: https://datasheet.octopart.com:443/CR0603-JW-102ELF-Bourns-datasheet-24895818.pdf?src-supplier=Farnell; ComponentLink10Description: https://datasheet.octopart.com:443/CR0603-JW-102ELF-Bourns-datasheet-22971629.pdf?src-supplier=Farnell; ComponentLink10URL: https://datasheet.octopart.com:443/CR0603-JW-102ELF-Bourns-datasheet-22971629.pdf?src-supplier=Farnell; ComponentLink11Description: https://datasheet.octopart.com:443/CR0603-JW-102ELF.-Bourns-datasheet-62114779.pdf?src-supplier=Farnell; ComponentLink11URL: https://datasheet.octopart.com:443/CR0603-JW-102ELF.-Bourns-datasheet-62114779.pdf?src-supplier=Farnell; ComponentLink12Description: https://datasheet.octopart.com:443/CR0603-JW-102ELF.-Bourns-datasheet-45190937.pdf?src-supplier=Farnell; ComponentLink12URL: https://datasheet.octopart.com:443/CR0603-JW-102ELF.-Bourns-datasheet-45190937.pdf?src-supplier=Farnell; ComponentLink13Description: https://datasheet.octopart.com:443/CR0603-JW-102ELF-Bourns-datasheet-140567134.pdf?src-supplier=Arrow.cn; ComponentLink13URL: https://datasheet.octopart.com:443/CR0603-JW-102ELF-Bourns-datasheet-140567134.pdf?src-supplier=Arrow.cn; ComponentLink14Description: https://datasheet.octopart.com:443/CR0603-JW-102ELF-Bourns-datasheet-66078858.pdf?src-supplier=Jameco+(USA); ComponentLink14URL: https://datasheet.octopart.com:443/CR0603-JW-102ELF-Bourns-datasheet-66078858.pdf?src-supplier=Jameco+(USA); ComponentLink15Description: http://sigma.octopart.com:80/conflict_mineral_statement/Bourns-company-36.pdf?src-supplier=Compliance+Statements; ComponentLink15URL: http://sigma.octopart.com:80/conflict_mineral_statement/Bourns-company-36.pdf?src-supplier=Compliance+Statements; Composition: Thick Film; Contact Plating: Lead, Tin; Country of Origin: Taiwan; Depth: 800µm; Export Control Classification Number (ECCN) Code: EAR99; Features: Moisture Resistant; Harmonized Tariff Schedule (HTS) Code: 8533.21.00.30; Height: 450µm; Introduction Date: 2003-06-20; Length: 1.6mm; Lifecycle Status: Production; Manufacturer Part Number: CR0603-JW-102ELF; Max Operating Temperature: 155°C; Military Standard: Not; Min Operating Temperature: -55°C; Mounting Technology: Surface Mount; Number of Terminals: 2; Number of Terminations: 2; Packaging: Cut Tape; Pins: 2; Power Rating: 100mW; Pricing: 1=0.1, 10=0.02, 25=0.0144, 50=0.0114, 100=0.0091, 250=0.00692, 500=0.0057, 1000=0.00475 (USD); Radiation Hardening: No; REACH SVHC: Yes; RoHS: Compliant; Schedule B: 8533210025, 8533210045, 8533210045|8533210045, 8533210045|8533210045|8533210045; Stock: 1020641; Supplier: DigiKey; Supplier Part Number: CR0603-JW-102ELFDKR-ND; Temperature Coefficient: 200ppm/°C; Termination: SMD/SMT; Tolerance: 5%; Width: 800µm; Working Voltage: 75V; Library Name: Tremelo_Pedal.SchLib
R7 = RT0805FRE071K1L: Fixed Resistor, Thin Film, 0.125W, 1100ohm, 150V, 1% +/-Tol, 50ppm/Cel, Surface Mount, 0805; Manufacturer: Yageo Group; Manufacturer Package Identifier: 0805 (2012 metric); Power Rating: 125mW; Temperature Coefficient: 50ppm/°C; Value: 1.1kΩ; Case Code (Imperial): 0805; Case/Package: 0805; Category: Chip SMD Resistors; China RoHS: Non-Compliant; Component Kind: Standard; ComponentLink1Description: https://datasheet.octopart.com:443/RT0805FRE071K1L-Yageo-datasheet-176402239.pdf?src-supplier=IHS; ComponentLink1URL: https://datasheet.octopart.com:443/RT0805FRE071K1L-Yageo-datasheet-176402239.pdf?src-supplier=IHS; ComponentLink2Description: https://datasheet.octopart.com:443/RT0805FRE071K1L-Yageo-datasheet-96443642.pdf?src-supplier=Upverter; ComponentLink2URL: https://datasheet.octopart.com:443/RT0805FRE071K1L-Yageo-datasheet-96443642.pdf?src-supplier=Upverter; ComponentLink3Description: https://datasheet.octopart.com:443/RT0805FRE071K1L-Yageo-Group-datasheet-193562488.pdf?src-supplier=element14+APAC; ComponentLink3URL: https://datasheet.octopart.com:443/RT0805FRE071K1L-Yageo-Group-datasheet-193562488.pdf?src-supplier=element14+APAC; ComponentLink4Description: https://datasheet.octopart.com:443/RT0805FRE071K1L-Yageo-Group-datasheet-188167888.pdf?src-supplier=LCSC; ComponentLink4URL: https://datasheet.octopart.com:443/RT0805FRE071K1L-Yageo-Group-datasheet-188167888.pdf?src-supplier=LCSC; ComponentLink5Description: https://datasheet.octopart.com/RT0805FRE071K1L-Yageo-datasheet-86813807.pdf; ComponentLink5URL: https://datasheet.octopart.com/RT0805FRE071K1L-Yageo-datasheet-86813807.pdf; ComponentLink6Description: http://sigma.octopart.com:80/conflict_mineral_statement/Yageo-company-3.pdf?src-supplier=Compliance+Statements; ComponentLink6URL: http://sigma.octopart.com:80/conflict_mineral_statement/Yageo-company-3.pdf?src-supplier=Compliance+Statements; ComponentLink7Description: http://sigma.octopart.com:80/rohs_statement/Yageo-company-2.pdf?src-supplier=Compliance+Statements; ComponentLink7URL: http://sigma.octopart.com:80/rohs_statement/Yageo-company-2.pdf?src-supplier=Compliance+Statements; Composition: Thin Film; Contact Plating: Tin; Country of Origin: Mainland China, Taiwan; Depth: 1.25mm; Export Control Classification Number (ECCN) Code: EAR99; Harmonized Tariff Schedule (HTS) Code: 8533.21.00.30; Height: 500µm; Introduction Date: 2008-07-11; Lead Free: Lead Free; Length: 2mm; Lifecycle Status: Production; Manufacturer Part Number: RT0805FRE071K1L; Max Operating Temperature: 155°C; Military Standard: Not; Min Operating Temperature: -55°C; Mounting Technology: Surface Mount; Number of Terminals: 2; Pricing: 1=0.11, 10=0.02, 50=0.0176, 100=0.0167, 500=0.01458 (USD); Radiation Hardening: No; REACH SVHC: Yes; RoHS: Compliant; Stock: 16794; Supplier: DigiKey; Supplier Part Number: 13-RT0805FRE071K1LDKR-ND; Termination: SMD/SMT; Tolerance: 1%; Voltage Rating: 150V; Voltage Rating (DC): 150V; Width: 1.25mm; Working Voltage: 150V; Library Name: Tremelo_Pedal.SchLib
R9 = MCT0603MD1000DP500: Fixed Resistor, Thin Film, 0.11W, 100ohm, 75V, 0.5% +/-Tol, 25ppm/Cel, Surface Mount, 0603; Manufacturer: Vishay; Max Power Dissipation: 150mW; Power Rating: 110mW; Temperature Coefficient: 25ppm/°C; Value: 100Ω; Case Code (Imperial): 0603; Case Code (Metric): 1608; Case/Package: 0603; Category: Chip SMD Resistors; China RoHS: Non-Compliant; Component Kind: Standard; ComponentLink1Description: https://datasheet.octopart.com:443/MCT0603MD1000DP500-Vishay-datasheet-182027964.pdf?src-supplier=IHS; ComponentLink1URL: https://datasheet.octopart.com:443/MCT0603MD1000DP500-Vishay-datasheet-182027964.pdf?src-supplier=IHS; ComponentLink2Description: https://datasheet.octopart.com:443/MCT0603MD1000DP500-Vishay-datasheet-114607041.pdf?src-supplier=Upverter; ComponentLink2URL: https://datasheet.octopart.com:443/MCT0603MD1000DP500-Vishay-datasheet-114607041.pdf?src-supplier=Upverter; ComponentLink3Description: https://datasheet.octopart.com:443/MCT0603MD1000DP500-Vishay-datasheet-181963140.pdf?src-supplier=Newark; ComponentLink3URL: https://datasheet.octopart.com:443/MCT0603MD1000DP500-Vishay-datasheet-181963140.pdf?src-supplier=Newark; ComponentLink4Description: https://datasheet.octopart.com:443/MCT0603MD1000DP500-Vishay-datasheet-62318330.pdf?src-supplier=element14+APAC; ComponentLink4URL: https://datasheet.octopart.com:443/MCT0603MD1000DP500-Vishay-datasheet-62318330.pdf?src-supplier=element14+APAC; ComponentLink5Description: https://datasheet.octopart.com:443/MCT0603MD1000DP500-Vishay-datasheet-8643000.pdf?src-supplier=element14+APAC; ComponentLink5URL: https://datasheet.octopart.com:443/MCT0603MD1000DP500-Vishay-datasheet-8643000.pdf?src-supplier=element14+APAC; ComponentLink6Description: https://datasheet.octopart.com:443/MCT0603MD1000DP500-Vishay-datasheet-141944504.pdf?src-supplier=Arrow.cn; ComponentLink6URL: https://datasheet.octopart.com:443/MCT0603MD1000DP500-Vishay-datasheet-141944504.pdf?src-supplier=Arrow.cn; ComponentLink7Description: https://datasheet.octopart.com:443/MCT0603MD1000DP500-Vishay-datasheet-27835074.pdf?src-supplier=HQonline; ComponentLink7URL: https://datasheet.octopart.com:443/MCT0603MD1000DP500-Vishay-datasheet-27835074.pdf?src-supplier=HQonline; ComponentLink8Description: http://sigma.octopart.com:80/rohs_statement/Vishay-company-15.pdf?src-supplier=Compliance+Statements; ComponentLink8URL: http://sigma.octopart.com:80/rohs_statement/Vishay-company-15.pdf?src-supplier=Compliance+Statements; Composition: Thin Film; Country of Origin: Germany, Israel; Export Control Classification Number (ECCN) Code: EAR99; Features: Anti-Sulfur, Automotive AEC-Q200; Harmonized Tariff Schedule (HTS) Code: 8533.21.00.30; Height: 450µm; Introduction Date: 2008-07-09; Length: 1.55mm; Lifecycle Status: Production; Manufacturer Part Number: MCT0603MD1000DP500; Max Operating Temperature: 125°C; Min Operating Temperature: -55°C; Mounting Technology: Surface Mount; Number of Terminals: 2; Number of Terminations: 2; Pins: 2; Pricing: 1=0.1, 10=0.084, 50=0.0738, 100=0.0696, 500=0.06114 (USD); Ratings: AEC-Q200; REACH SVHC: Yes; RoHS: Compliant; Schedule B: 8533210045, 8533210045|8533210045|8533210045|8533210045|8533210045; Stock: 11446; Supplier: DigiKey; Supplier Part Number: MCT0603-100-MDCT-ND; Termination: SMD/SMT; Tolerance: 0.5%; Voltage Rating: 75V; Voltage Rating (DC): 75V; Weight: 2.012816mg; Width: 850µm; Working Voltage: 75V; Library Name: Tremelo_Pedal.SchLib
R10 R11 = CPF0603B1M0E1: 63mW Thin Film Resistor 50V ±25ppm/℃ ±0.1% 1MΩ 0603 Chip Resistor - Surface Mount ROHS; Manufacturer: TE Connectivity; Manufacturer Lifecycle Status: ACTIVE; Manufacturer Part Number: 1-1879417-9; Number of Resistors: 1; Temperature Coefficient: 25ppm/°C; Case Code (Imperial): 0603; Case Code (Metric): 1608; Case/Package: 0603; Category: Chip SMD Resistors; China RoHS: Compliant; Component Kind: Standard; ComponentLink1Description: https://datasheet.octopart.com:443/1-1879417-9-TE-Connectivity-datasheet-170667665.pdf?src-supplier=element14+APAC; ComponentLink1URL: https://datasheet.octopart.com:443/1-1879417-9-TE-Connectivity-datasheet-170667665.pdf?src-supplier=element14+APAC; ComponentLink2Description: https://datasheet.octopart.com:443/CPF0603B1M0E1-TE-Connectivity-datasheet-66077623.pdf?src-supplier=element14+APAC; ComponentLink2URL: https://datasheet.octopart.com:443/CPF0603B1M0E1-TE-Connectivity-datasheet-66077623.pdf?src-supplier=element14+APAC; ComponentLink3Description: https://datasheet.octopart.com:443/1-1879417-9-TE-Connectivity-datasheet-136771900.pdf?src-supplier=Electro+Sonic; ComponentLink3URL: https://datasheet.octopart.com:443/1-1879417-9-TE-Connectivity-datasheet-136771900.pdf?src-supplier=Electro+Sonic; ComponentLink4Description: https://datasheet.octopart.com:443/CPF0603B1M0E1-Tyco-Electronics-datasheet-11120060.pdf?src-supplier=Electro+Sonic; ComponentLink4URL: https://datasheet.octopart.com:443/CPF0603B1M0E1-Tyco-Electronics-datasheet-11120060.pdf?src-supplier=Electro+Sonic; ComponentLink5Description: https://datasheet.octopart.com:443/1-1879417-9-AMP-datasheet-17911634.pdf?src-supplier=Master+Electronics; ComponentLink5URL: https://datasheet.octopart.com:443/1-1879417-9-AMP-datasheet-17911634.pdf?src-supplier=Master+Electronics; ComponentLink6Description: https://datasheet.octopart.com:443/1-1879417-9-TE-Connectivity-datasheet-78339038.pdf?src-supplier=Master+Electronics; ComponentLink6URL: https://datasheet.octopart.com:443/1-1879417-9-TE-Connectivity-datasheet-78339038.pdf?src-supplier=Master+Electronics; ComponentLink7Description: https://datasheet.octopart.com:443/CPF0603B1M0E1-TE-Connectivity-datasheet-11120284.pdf?src-supplier=Master+Electronics; ComponentLink7URL: https://datasheet.octopart.com:443/CPF0603B1M0E1-TE-Connectivity-datasheet-11120284.pdf?src-supplier=Master+Electronics; ComponentLink8Description: https://datasheet.octopart.com:443/CPF0603B1M0E1-TE-Connectivity-datasheet-62056503.pdf?src-supplier=Master+Electronics; ComponentLink8URL: https://datasheet.octopart.com:443/CPF0603B1M0E1-TE-Connectivity-datasheet-62056503.pdf?src-supplier=Master+Electronics; ComponentLink9Description: https://datasheet.octopart.com:443/1-1879417-9-TE-Connectivity---AMP-datasheet-187588164.pdf?src-supplier=Master+Electronics; ComponentLink9URL: https://datasheet.octopart.com:443/1-1879417-9-TE-Connectivity---AMP-datasheet-187588164.pdf?src-supplier=Master+Electronics; ComponentLink10Description: https://datasheet.octopart.com:443/1-1879417-9-TE-Connectivity-datasheet-158231717.pdf?src-supplier=IHS; ComponentLink10URL: https://datasheet.octopart.com:443/1-1879417-9-TE-Connectivity-datasheet-158231717.pdf?src-supplier=IHS; ComponentLink11Description: https://datasheet.octopart.com:443/CPF0603B1M0E1-TE-Connectivity-datasheet-141913849.pdf?src-supplier=Upverter; ComponentLink11URL: https://datasheet.octopart.com:443/CPF0603B1M0E1-TE-Connectivity-datasheet-141913849.pdf?src-supplier=Upverter; ComponentLink12Description: https://datasheet.octopart.com:443/1-1879417-9-TE-Connectivity-datasheet-128065679.pdf?src-supplier=Sager+Electronics; ComponentLink12URL: https://datasheet.octopart.com:443/1-1879417-9-TE-Connectivity-datasheet-128065679.pdf?src-supplier=Sager+Electronics; ComponentLink13Description: https://datasheet.octopart.com:443/1-1879417-9-Tyco-Electronics-datasheet-11120073.pdf?src-supplier=TE+Connectivity; ComponentLink13URL: https://datasheet.octopart.com:443/1-1879417-9-Tyco-Electronics-datasheet-11120073.pdf?src-supplier=TE+Connectivity; ComponentLink14Description: https://datasheet.octopart.com:443/CPF0603B1M0E1-TE-Connectivity---Neohm-datasheet-193183134.pdf?src-supplier=JRH+Electronics; ComponentLink14URL: https://datasheet.octopart.com:443/CPF0603B1M0E1-TE-Connectivity---Neohm-datasheet-193183134.pdf?src-supplier=JRH+Electronics; ComponentLink15Description: https://datasheet.octopart.com:443/CPF0603B1M0E1-Neohm-datasheet-140860410.pdf?src-supplier=JRH+Electronics; ComponentLink15URL: https://datasheet.octopart.com:443/CPF0603B1M0E1-Neohm-datasheet-140860410.pdf?src-supplier=JRH+Electronics; ComponentLink16Description: https://datasheet.octopart.com:443/1-1879417-9-TE-Connectivity---AMP-datasheet-189108484.pdf?src-supplier=JRH+Electronics; ComponentLink16URL: https://datasheet.octopart.com:443/1-1879417-9-TE-Connectivity---AMP-datasheet-189108484.pdf?src-supplier=JRH+Electronics; ComponentLink17Description: https://datasheet.octopart.com:443/CPF0603B1M0E1-TE-Connectivity-datasheet-17282431.pdf?src-supplier=Future+Electronics; ComponentLink17URL: https://datasheet.octopart.com:443/CPF0603B1M0E1-TE-Connectivity-datasheet-17282431.pdf?src-supplier=Future+Electronics; ComponentLink18Description: https://datasheet.octopart.com:443/CPF0603B1M0E1-TE-Connectivity-datasheet-16487054.pdf?src-supplier=Farnell; ComponentLink18URL: https://datasheet.octopart.com:443/CPF0603B1M0E1-TE-Connectivity-datasheet-16487054.pdf?src-supplier=Farnell; ComponentLink19Description: http://sigma.octopart.com:80/14782993/rohs_certificate/TE-Connectivity-CPF0603B1M0E1.pdf?src-supplier=Compliance+Statements; ComponentLink19URL: http://sigma.octopart.com:80/14782993/rohs_certificate/TE-Connectivity-CPF0603B1M0E1.pdf?src-supplier=Compliance+Statements; ComponentLink20Description: http://sigma.octopart.com:80/38872629/materials_sheet/TE-Connectivity-CPF0603B1M0E1.pdf?src-supplier=Compliance+Statements; ComponentLink20URL: http://sigma.octopart.com:80/38872629/materials_sheet/TE-Connectivity-CPF0603B1M0E1.pdf?src-supplier=Compliance+Statements; ComponentLink21Description: http://sigma.octopart.com:80/78339069/materials_sheet/TE-Connectivity-CPF0603B1M0E1.pdf?src-supplier=Compliance+Statements; ComponentLink21URL: http://sigma.octopart.com:80/78339069/materials_sheet/TE-Connectivity-CPF0603B1M0E1.pdf?src-supplier=Compliance+Statements; Composition: Thin Film; Depth: 800µm; ELV: Compliant; Export Control Classification Number (ECCN) Code: EAR99; Harmonized Tariff Schedule (HTS) Code: 8533.21.00.30; Height: 450µm; Introduction Date: 2009-09-20; Lead Free: Lead Free; Length: 1.55mm; Lifecycle Status: Production; Max Operating Temperature: 155°C; Max Power Dissipation: 63mW; Military Standard: Not; Min Operating Temperature: -55°C; Mounting Technology: Surface Mount; Number of Terminals: 2; Number of Terminations: 2; Operating Supply Voltage: 50V; Pins: 2; Power Rating: 63mW; Pricing: 1=0.24, 10=0.197, 50=0.1728, 100=0.1633, 500=0.14384, 1000=0.13667, 3000=0.12678 (USD); Radiation Hardening: No; REACH SVHC: Yes; RoHS: Compliant; Schedule B: 8533210045, 8533210080, 8533210080|8533210080, 8533210080|8533210080|8533210080; Stock: 586437; Supplier: DigiKey; Supplier Part Number: A102234CT-ND; Termination: Solder; Tolerance: 0.1%; Value: 1MΩ; Voltage Rating: 50V; Voltage Rating (DC): 50V; Weight: 2.012816mg; Width: 800µm; Working Voltage: 50V; Library Name: Tremelo_Pedal.SchLib
R12 R13 = AC0603FR-0710K7L: Res Thick Film 0603 10.7K Ohm 1% 0.1W(1/10W) ±100ppm/C Pad SMD T/R Automotive AEC-Q200; Component Kind: Standard; Library Name: Tremelo_Pedal.SchLib
